# Altium schematic sheet [01] Power Suppy.SchDoc
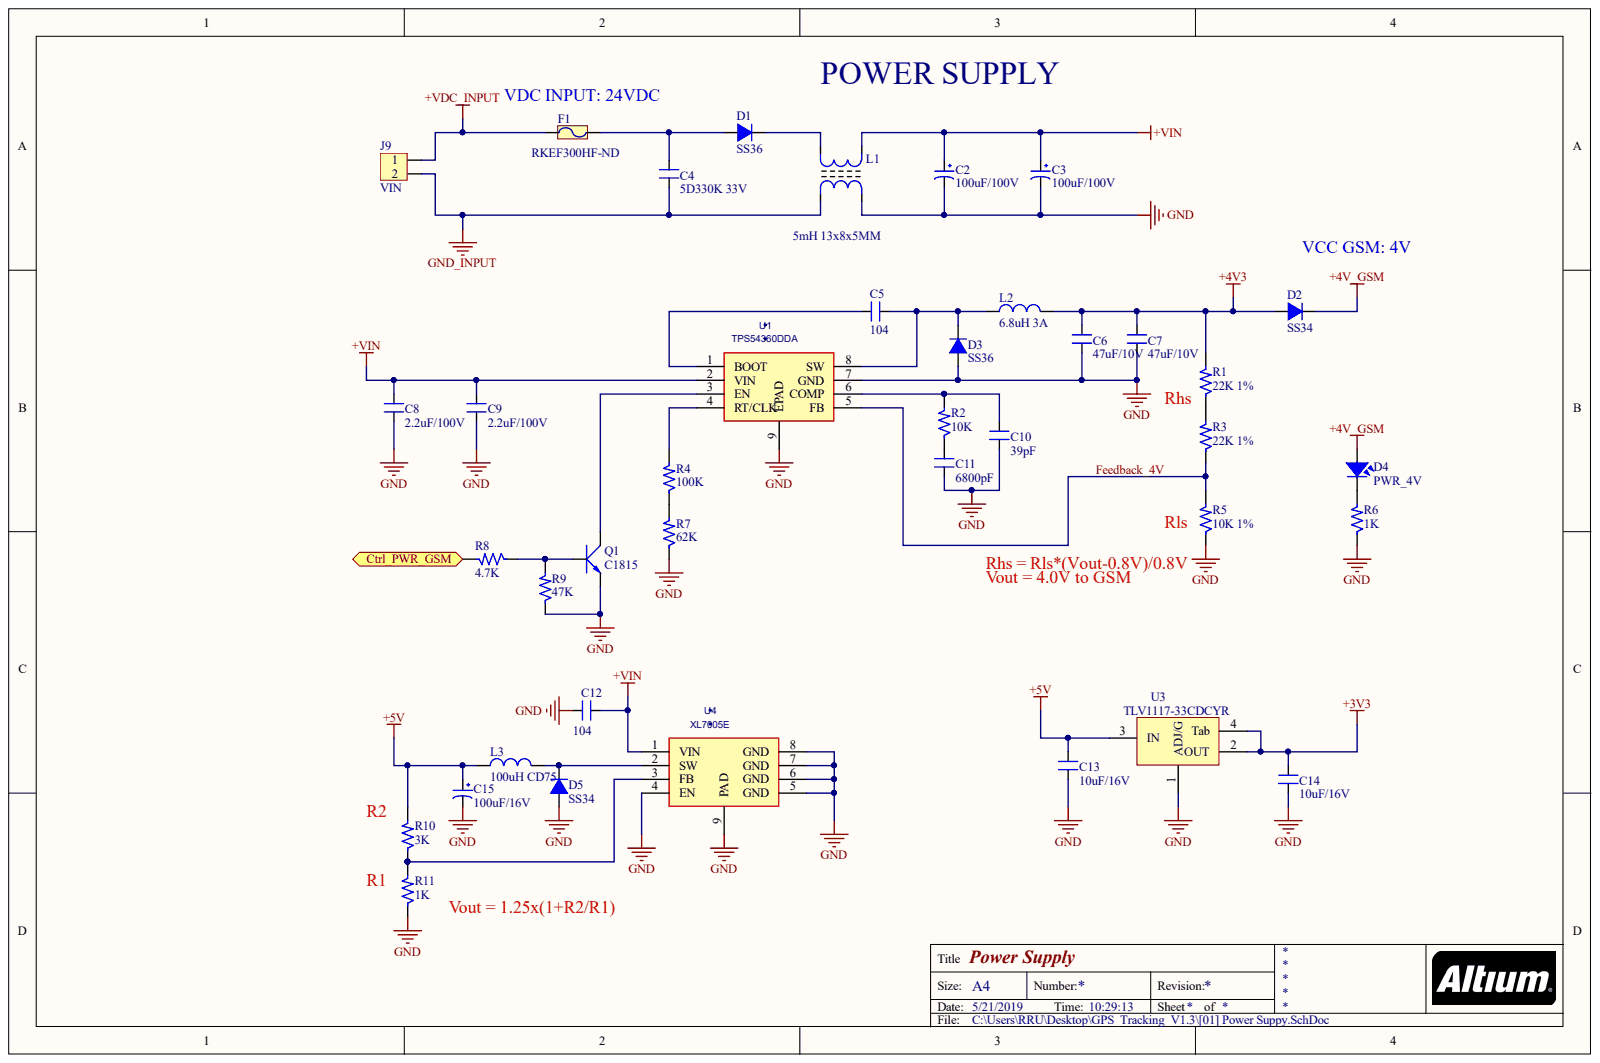
[diagram p0: [01] Power Suppy.SchDoc]

## Parts
C2  100uF/100V  [CP1.5/3.4]
C3  100uF/100V  [CP1.5/3.4]
C4  5D330K 33V  [CN2]
C5  104  [C0603 SMT]
C6  47uF/10V  [SM-1210]
C7  47uF/10V  [SM-1210]
C8  2.2uF/100V  [SM-1210]
C9  2.2uF/100V  [SM-1210]
C10  39pF  [C0603 SMT]
C11  6800pF  [C0603 SMT]
C12  104  [C0603 SMT]
C13  10uF/16V  [C0603 SMT]
C14  10uF/16V  [C0603 SMT]
C15  100uF/16V  [C_Alu_6.3x7.7]
D1  SS36  [DO-214AB SMA]
D2  SS34  [DO-214AB SMA]
D3  SS36  [DO-214AB SMA]
D4  PWR_4V  [Led_0603_Green]
D5  SS34  [DO-214AB SMA]
F1  RKEF300HF-ND  [FUSE2]
J9  VIN  [Hed2V_3.96]
L1  5mH 13x8x5MM  [L2 UF9.8]
L2  6.8uH 3A  [L01 SMD 12*12*6]
L3  100uH CD75  [L01 CD75]
Q1  C1815  [SOT23B]
R1  22K 1%  [R0603 -SMT]
R2  10K  [R0603 -SMT]
R3  22K 1%  [R0603 -SMT]
R4  100K  [R0603 -SMT]
R5  10K 1%  [R0603 -SMT]
R6  1K  [R0603 -SMT]
R7  62K  [R0603 -SMT]
R8  4.7K  [R0603 -SMT]
R9  47K  [R0603 -SMT]
R10  3K  [R0603 -SMT]
R11  1K  [R0603 -SMT]
U1  TPS54360DDA  [DDA8_3P1X2P4-M]
U3  TLV1117-33CDCYR  [SOT223-4N]
U4  XL7005E  [DDA8_3P1X2P4-M]

## Ports
CTRL_PWR_GSM

## Nets
+3V3: C14.2 U3.2(OUT) U3.4(Tab)
+4V3: C6.2 C7.2 D2.1 L2.2 R1.2
+4V_GSM: D2.2 D4.1
+5V: C13.2 C15.1 L3.1 R10.2 U3.3(IN)
+VDC_INPUT: F1.1 J9.1
+VIN: C2.1 C3.1 C8.2 C9.2 C12.2 L1.3 U1.2(VIN) U4.1(VIN)
GND: C2.2 C3.2 C6.1 C7.1 C8.1 C9.1 C10.1 C11.1 C12.1 C13.1 C14.1 C15.2 D3.1 D5.1 L1.2 Q1.1 R5.1 R6.1 R7.1 R9.1 R11.1 U1.7(GND) U1.9(EPAD) U3.1(ADJ/G) U4.4(EN) U4.5(GND) U4.6(GND) U4.7(GND) U4.8(GND) U4.9(PAD)
GND_INPUT: C4.1 J9.2 L1.1
FEEDBACK_4V: R3.1 R5.2 U1.5(FB)
NetC4_2: C4.2 D1.1 F1.2
NetC5_1: C5.1 U1.1(BOOT)
NetC5_2: C5.2 D3.2 L2.1 U1.8(SW)
NetC10_2: C10.2 R2.2 U1.6(COMP)
NetC11_2: C11.2 R2.1
NetD1_2: D1.2 L1.4
NetD4_2: D4.2 R6.2
NetD5_2: D5.2 L3.2 U4.2(SW)
NetQ1_2: Q1.2 U1.3(EN)
NetQ1_3: Q1.3 R8.1 R9.2
NetR1_1: R1.1 R3.2
NetR4_1: R4.1 R7.2
NetR4_2: R4.2 U1.4(RT/CLK)
NetR8_2: R8.2 ; port CTRL_PWR_GSM
NetR10_1: R10.1 R11.2 U4.3(FB)

## Library data of the parts
C2 C3 = CP4: Cap 1000uF/16V - 220uF/50V; Description 1: 2200uF-3300uF/6,3V - 1000uF-2200uF/10V; Description 2: 1000uF/16V - 470uF => 1000uF/25V - 100uF/100V; Description 3: 330uF>470uF/35V_220uF>470uF/50V_10uF>22uF/250V; Description 4: 220uF => 330uF/63V - 10uF =>33uF/160 - 200V; Description 5: 4,7uF>10uF/250V_3,3uF>10uF/400V - 2,2uF>4,7uF/450V; Component Kind: Standard; Value: 1000uF/16V; Library Name: khapham.IntLib
C4 = CN: Designed by: VanDungEvn; Value: 0,1uF/300V; Component Kind: Standard; Description: 5D330K 33V; Library Name: khapham.IntLib
C5 C10-C14 = CM: Cap SMD; Value: 103; Component Kind: Standard; Library Name: khapham.IntLib
C6 = CM: CAP CER 47UF 10V X5R 1210; Value: 103; Component Kind: Standard; Library Name: khapham.IntLib
C7 = CM: CAP CER 47UF 10V X5R 1210 1276-3380-2-ND; Value: 103; Component Kind: Standard; Library Name: khapham.IntLib
C8 C9 = CM: CAP CER 2.2UF 100V X7R 1210  445-4498-2-ND; Value: 103; Component Kind: Standard; Library Name: khapham.IntLib
C15 = C-Alu-Tantalum: Cap Aluminum, Tantalum 7343; Description 1: 10 => 220uF/6,3V/10V - 10uF => 100uF/16V; Description 2: 0,1uF => 33uF/50V - 10uF => 22uF/63V; Description 3: 0,47uF => 10uF/100V; Value: 10uF/50V; Component Kind: Standard; Library Name: khapham.IntLib
D1 D3 = D5A: DIODE SCHOTTKY 60V 3A SMA; Value: 1N5408; Component Kind: Standard; Library Name: khapham.IntLib
D2 D5 = D1A: DIODE SCHOTTKY 40V 3A SMC; Value: 1N4007; Component Kind: Standard; Library Name: khapham.IntLib
D4 = LED: LED 0603 RED; Value: LED 3 ly Single Colour; Component Kind: Standard; Library Name: khapham.IntLib
F1 = Fuse: PTC RESET FUSE 60V 3A RADIAL; Designed by: VanDungEvn; Component Kind: Standard; Value: Fuse; Library Name: khapham.IntLib
J9 = Hed2: Header 2 (Green); Component Kind: Standard; Value: Header 2; Library Name: khapham.IntLib
L1 = L2x220: Designed by: VanDungEvn; Value: Loc 220; Component Kind: Standard; Description: 5mH 13x8x5MM; Library Name: khapham.IntLib
L2 = L1: 6.8uH 3A 12x12x7MM; Value: 150 mH; Component Kind: Standard; Library Name: khapham.IntLib
L3 = L1: Value: 150 mH; Component Kind: Standard; Description: 100uH CD75; Library Name: khapham.IntLib
Q1 = C1815: NPN 600mW, ECB; : C828; : C2383; Component Kind: Standard; Value: C1815; Library Name: khapham.IntLib
R1-R5 R7-R10 = RM: Resistor SMD; Value: 1K; Component Kind: Standard; Library Name: khapham.IntLib
R6 R11 = RM: Resistor SMD; Component Kind: Standard; Value: 1K; Library Name: khapham.IntLib
U1 = TPS54360DDA: REG BUCK SPLIT RAIL 8SOPWRPAD; Built_By: EMA_UL_Team; C: Copyright (C) 2015 Accelerated Designs. All rights reserved; Vendor: TEXAS INSTRUMENTS; Component Kind: Standard; Manufacturer_Part_Number: TPS54360DDA; Value: TPS54360DDA; Library Name: khapham.IntLib
U3 = LM1117: 3v3; Value: LM317; Component Kind: Standard; Library Name: khapham.IntLib
U4 = XL7005: REG BUCK SPLIT RAIL 8SOPWRPAD; Manufacturer_Part_Number: TPS54360DDA; Built_By: EMA_UL_Team; C: Copyright (C) 2015 Accelerated Designs. All rights reserved; Value: TPS54360DDA; Vendor: TEXAS INSTRUMENTS; Component Kind: Standard; Library Name: khapham.IntLib
